# Altium schematic sheet 4G.SchDoc(4G)
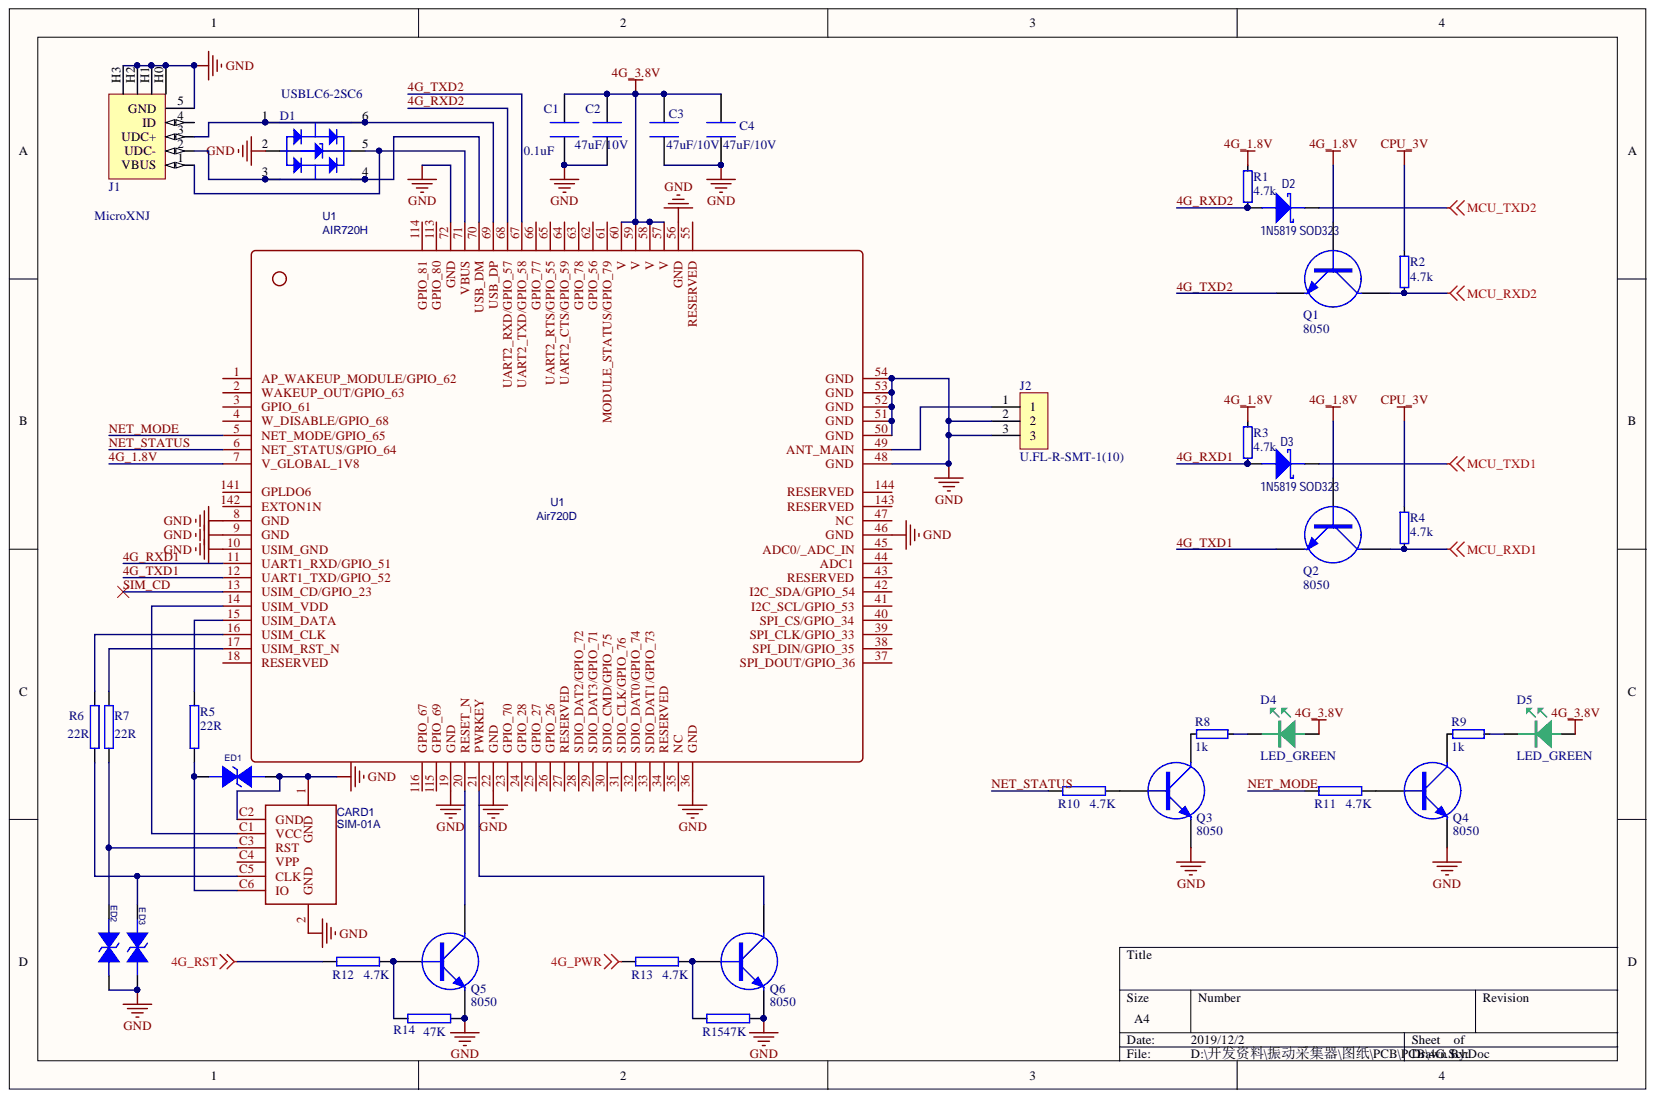
[diagram p0: 4G.SchDoc]

## Parts
C1  0.1uF  [0402_C]
C2  47uF/10V  [0805_C]
C3  47uF/10V  [0805_C]
C4  47uF/10V  [0805_C]
CARD1  SIM-01A  [16.4MM*14.75MM]
D1  USBLC6-2SC6  [SC-70-6(SOT-363)]
D2  1N5819 SOD323  [SOD-323]
D3  1N5819 SOD323  [SOD-323]
D4  LED_GREEN  [0603_LED_S1]
D5  LED_GREEN  [0603_LED_S1]
ED1  ESD3Z5.0C  [SOD323]  (value not drawn)
ED2  ESD3Z5.0C  [SOD323]  (value not drawn)
ED3  ESD3Z5.0C  [SOD323]  (value not drawn)
J1  MicroXNJ  [USB-005H]
J2  U.FL-R-SMT-1(10)  [IPEX3x3]
Q1  8050  [SOT-23(SOT-23-3)]
Q2  8050  [SOT-23(SOT-23-3)]
Q3  8050  [SOT-23(SOT-23-3)]
Q4  8050  [SOT-23(SOT-23-3)]
Q5  8050  [SOT-23(SOT-23-3)]
Q6  8050  [SOT-23(SOT-23-3)]
R1  4.7k  [0402]
R2  4.7k  [0402]
R3  4.7k  [0402]
R4  4.7k  [0402]
R5  22R  [0402_R]
R6  22R  [0402_R]
R7  22R  [0402_R]
R8  1k  [0402]
R9  1k  [0402]
R10  4.7K  [0402_R]
R11  4.7K  [0402_R]
R12  4.7K  [0402_R]
R13  4.7K  [0402_R]
R14  47K  [0402_R]
R15  47K  [0402_R]  (value not drawn)
U1  AIR720H  [COMM-SMD_AIR720H]

## Nets
4G_3.8V: C1.1 C2.1 C3.1 C4.1 D4.A D5.A U1.57(V) U1.58(V) U1.59(V) U1.60(V)
4G_PWR: R13.2
4G_RST: R12.2
CPU_3V: R2.2 R4.2
GND: C1.2 C2.2 C3.2 C4.2 CARD1.1(GND) CARD1.2(GND) CARD1.C2(GND) D1.2 ED1.2 ED2.2 ED3.2 J1.5(GND) J1.H0 J1.H1 J1.H2 J1.H3 J2.2 J2.3 Q3.2 Q4.2 Q5.2 Q6.2 R14.1 R15.1 U1.8(GND) U1.9(GND) U1.10(USIM_GND) U1.19(GND) U1.22(GND) U1.36(GND) U1.46(GND) U1.48(GND) U1.50(GND) U1.51(GND) U1.52(GND) U1.53(GND) U1.54(GND) U1.56(GND) U1.72(GND)
MCU_RXD1: Q2.3 R4.1
MCU_RXD2: Q1.3 R2.1
MCU_TXD1: D3.C
MCU_TXD2: D2.C
4G_1.8V: Q1.1 Q2.1 R1.2 R3.2 U1.7(V_GLOBAL_1V8)
4G_RXD1: D3.A R3.1 U1.11(UART1_RXD/GPIO_51)
4G_RXD2: D2.A R1.1 U1.68(UART2_RXD/GPIO_57)
4G_TXD1: Q2.2 U1.12(UART1_TXD/GPIO_52)
4G_TXD2: Q1.2 U1.67(UART2_TXD/GPIO_58)
NET_MODE: R11.2 U1.5(NET_MODE/GPIO_65)
NET_STATUS: R10.2 U1.6(NET_STATUS/GPIO_64)
SIM_CD: U1.13(USIM_CD/GPIO_23)
NetCARD1_C1: CARD1.C1(VCC) U1.14(USIM_VDD)
NetCARD1_C3: CARD1.C3(RST) ED2.1 R7.2
NetCARD1_C5: CARD1.C5(CLK) ED3.1 R6.2
NetCARD1_C6: CARD1.C6(IO) ED1.1 R5.2
NetD1_1: D1.1 D1.6 J1.3(UDC+) U1.69(USB_DP)
NetD1_3: D1.3 D1.4 J1.2(UDC-) U1.70(USB_DM)
NetD1_5: D1.5 J1.1(VBUS) U1.71(VBUS)
NetD4_K: D4.K R8.2
NetD5_K: D5.K R9.2
NetJ2_1: J2.1 U1.49(ANT_MAIN)
NetQ3_1: Q3.1 R10.1
NetQ3_3: Q3.3 R8.1
NetQ4_1: Q4.1 R11.1
NetQ4_3: Q4.3 R9.1
NetQ5_1: Q5.1 R12.1 R14.2
NetQ5_3: Q5.3 U1.20(RESET_N)
NetQ6_1: Q6.1 R13.1 R15.2
NetQ6_3: Q6.3 U1.21(PWRKEY)
NetR5_1: R5.1 U1.15(USIM_DATA)
NetR6_1: R6.1 U1.16(USIM_CLK)
NetR7_1: R7.1 U1.17(USIM_RST_N)

Not connected: CARD1 C4(VPP); J1 4(ID); U1 1(AP_WAKEUP_MODULE/GPIO_62) 2(WAKEUP_OUT/GPIO_63) 3(GPIO_61) 4(W_DISABLE/GPIO_68) 18(RESERVED) 23(GPIO_70) 24(GPIO_28) 25(GPIO_27) 26(GPIO_26) 27(RESERVED) 28(SDIO_DAT2/GPIO_72) 29(SDIO_DAT3/GPIO_71) 30(SDIO_CMD/GPIO_75) 31(SDIO_CLK/GPIO_76) 32(SDIO_DAT0/GPIO_74) 33(SDIO_DAT1/GPIO_73) 34(RESERVED) 35(NC) 37(SPI_DOUT/GPIO_36) 38(SPI_DIN/GPIO_35) 39(SPI_CLK/GPIO_33) 40(SPI_CS/GPIO_34) 41(I2C_SCL/GPIO_53) 42(I2C_SDA/GPIO_54) 43(RESERVED) 44(ADC1) 45(ADC0/_ADC_IN) 47(NC) 55(RESERVED) 61(MODULE_STATUS/GPIO_79) 62(GPIO_56) 63(GPIO_78) 64(UART2_CTS/GPIO_59) 65(UART2_RTS/GPIO_55) 66(GPIO_77) 113(GPIO_80) 114(GPIO_81) 115(GPIO_69) 116(GPIO_67) 141(GPLDO6) 142(EXTON1N) 143(RESERVED) 144(RESERVED)

## Library data of the parts
C1 = STD-06-0048: CHIP CAPACITOR, CERAMIC, 100NF, 16V, 10%, X7R, 0402; Manufacturer: Yageo; Manufacturer Part Number: CC0402KRX7R7BB104; Approx Volume Cost ($): 0.003; Database Table Name: MLCC$; VALUE: 0.1; Component Kind: Standard; Dielectric: X7R; Part Number: STD-06-0048; Rated Voltage: 16V; Tolerance: 10%; Library Name: JG_StandardLibrary.DbLib
C2-C4 = STD-06-0048: CHIP CAPACITOR, CERAMIC, 100NF, 16V, 10%, X7R, 0402; Manufacturer: Yageo; Manufacturer Part Number: CC0402KRX7R7BB104; Approx Volume Cost ($): 0.003; Database Table Name: MLCC$; VALUE: 100N; Component Kind: Standard; Dielectric: X7R; Part Number: STD-06-0048; Rated Voltage: 16V; Tolerance: 10%; Library Name: JG_StandardLibrary.DbLib
CARD1 = SIM-01A: BOM_Manufacturer: HRO; BOM_Manufacturer Part: SIM-01A; BOM_Supplier: LCSC; BOM_Supplier Part: C99403; Component Kind: Standard; spicePre: C
D1 = D_ESD_PRTR5V0U2F_115: Ultra low capacitance double rail-to-rail ESD protection; MANUFACTURER: NXP; Component Kind: Standard; FARNELL: 2459738; PART: PRTR5V0U2F_115; Library Name: Arbury_SCH.SchLib
D2 D3 = DIO-SCH: Diode schottky; Component Kind: Standard; Library Name: Diodes_cn.SCHLIB
D4 D5 = LED_0603_GREEN: MANUFACTURER: KINGBRIGHT; PART: KP-3216CGCK; Component Kind: Standard; Description: LED_0603_GREEN; FARNELL: 2290333; Library Name: Arbury_SCH.SchLib
ED1-ED3 = DIODE BI-DIR TRIG: ESD; Component Kind: Standard
J1 = CON_USB_MICRO_AB_MOLEX: USB Micro-AB socket Molex 47589-0001; RS: 702-5488; Component Kind: Standard; Farnell: 156-8023; MANUFACTURER: Molex; PART: 47589-0001; Library Name: Arbury_CON.SchLib
J2 = SIP3: Component Kind: Standard; Library Name: PCB_Project.SCHLIB
Q1-Q6 = NPN: NPN Transistor; Component Kind: Standard
R1-R4 R8 R9 = R0402-12k: Resistor, ?%, 0.063W; Artikkelnr Noca: 27049099; Database Table Name: Resistors$; Note: Chip Res 1%; Value: 12k; Component Kind: Standard; LatestRevisionDate: 2009-11-23; Library Name: Nordic Passive DB.DbLib; Part Number: R0402-12k; Pin Count: 2; Published: 2009-05-08; Supplier: Farnell; Supplier part number: 173-8865; Publisher: Nordic Semiconductor
R5-R7 R10-R15 = RES2: Component Kind: Standard
U1 = AIR720H: BOM_Manufacturer: Luat; subpart_no: 1; Contributor: LCSC; BOM_Manufacturer Part: Air720H; BOM_Supplier: LCSC; BOM_Supplier Part: C408978; Component Kind: Standard; spicePre: U
